# Altium schematic sheet 03_UART.SchDoc(03_UART)
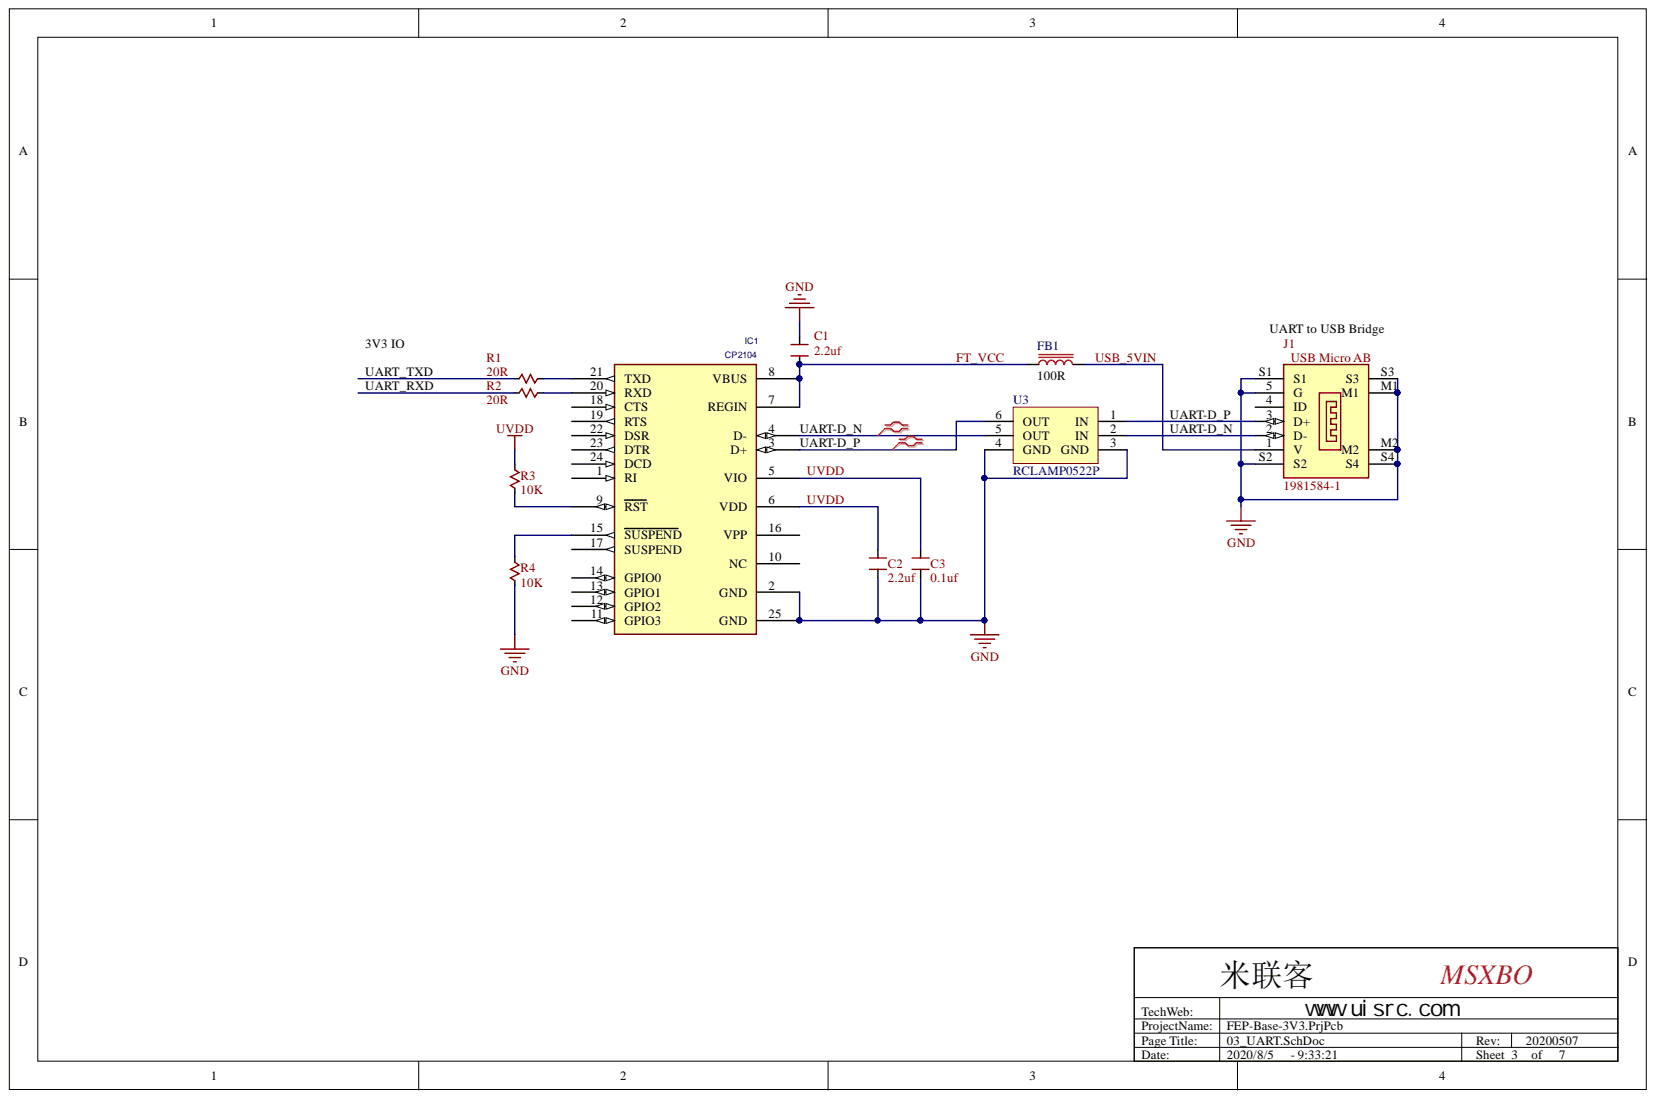
[diagram p0: 03_UART.SchDoc]

## Parts
C1  2.2uf  [CAP0402]
C2  2.2uf  [CAP0402]
C3  0.1uf  [CAP0402]
FB1  value 100R  [CAP0603]  (hidden comment: MPZ2012S601A)
IC1  CP2104  [QFN24_50P4X4X1]
J1  1981584-1  [MicroUsb]
R1  20R  [RES0402]
R2  20R  [RES0402]
R3  10K  [RES0402]
R4  10K  [RES0402]
U3  RCLAMP0522P  [SLP1610P4_6]

## Nets
GND: C1.1 C2.1 C3.1 IC1.2(GND) IC1.25(GND) J1.5(G) J1.M1 J1.M2 J1.S1 J1.S2 J1.S3 J1.S4 R4.1 U3.3(GND) U3.4(GND)
FT_VCC: C1.2 FB1.1 IC1.7(REGIN) IC1.8(VBUS)
UART-D_N: IC1.4(D-) J1.2(D-) U3.2(IN) U3.5(OUT)
UART-D_P: IC1.3(D+) J1.3(D+) U3.1(IN) U3.6(OUT)
UART_RXD: R2.1
UART_TXD: R1.1
USB_5VIN: FB1.2 J1.1(V)
UVDD: C2.2 C3.2 IC1.5(VIO) IC1.6(VDD) R3.2
NetIC1_9: IC1.9(RST) R3.1
NetIC1_15: IC1.15(SUSPEND) R4.2
NetIC1_20: IC1.20(RXD) R2.2
NetIC1_21: IC1.21(TXD) R1.2

Not connected: IC1 1(RI) 10(NC) 11(GPIO3) 12(GPIO2) 13(GPIO1) 14(GPIO0) 16(VPP) 17(SUSPEND) 18(CTS) 19(RTS) 22(DSR) 23(DTR) 24(DCD); J1 4(ID)

## Library data of the parts
C1-C3 = CAPACITOR: Generic Capacitor; Component Kind: Standard; Library Name: Miz702fun_20160501.IntLib
FB1 = Ferrite Bead: Generic Ferrite Bead; Component Kind: Standard; Library Name: Digilent.IntLib
J1 = TE 1981584: USB Micro AB connector; Manufacturer: Tyco Electronics; Component Kind: Standard; Library Name: MZ702N.IntLib
R1-R4 = RESISTOR: Generic Resistor; Value: 390; Component Kind: Standard; Library Name: Miz702fun_20160501.IntLib
IC1 = CP2104: Component Kind: Standard
U3 = RCLAMP0522P: Component Kind: Standard; Library Name: MiZ7020N_定制.SCHLIB
